# Altium schematic sheet loratea.SchDoc
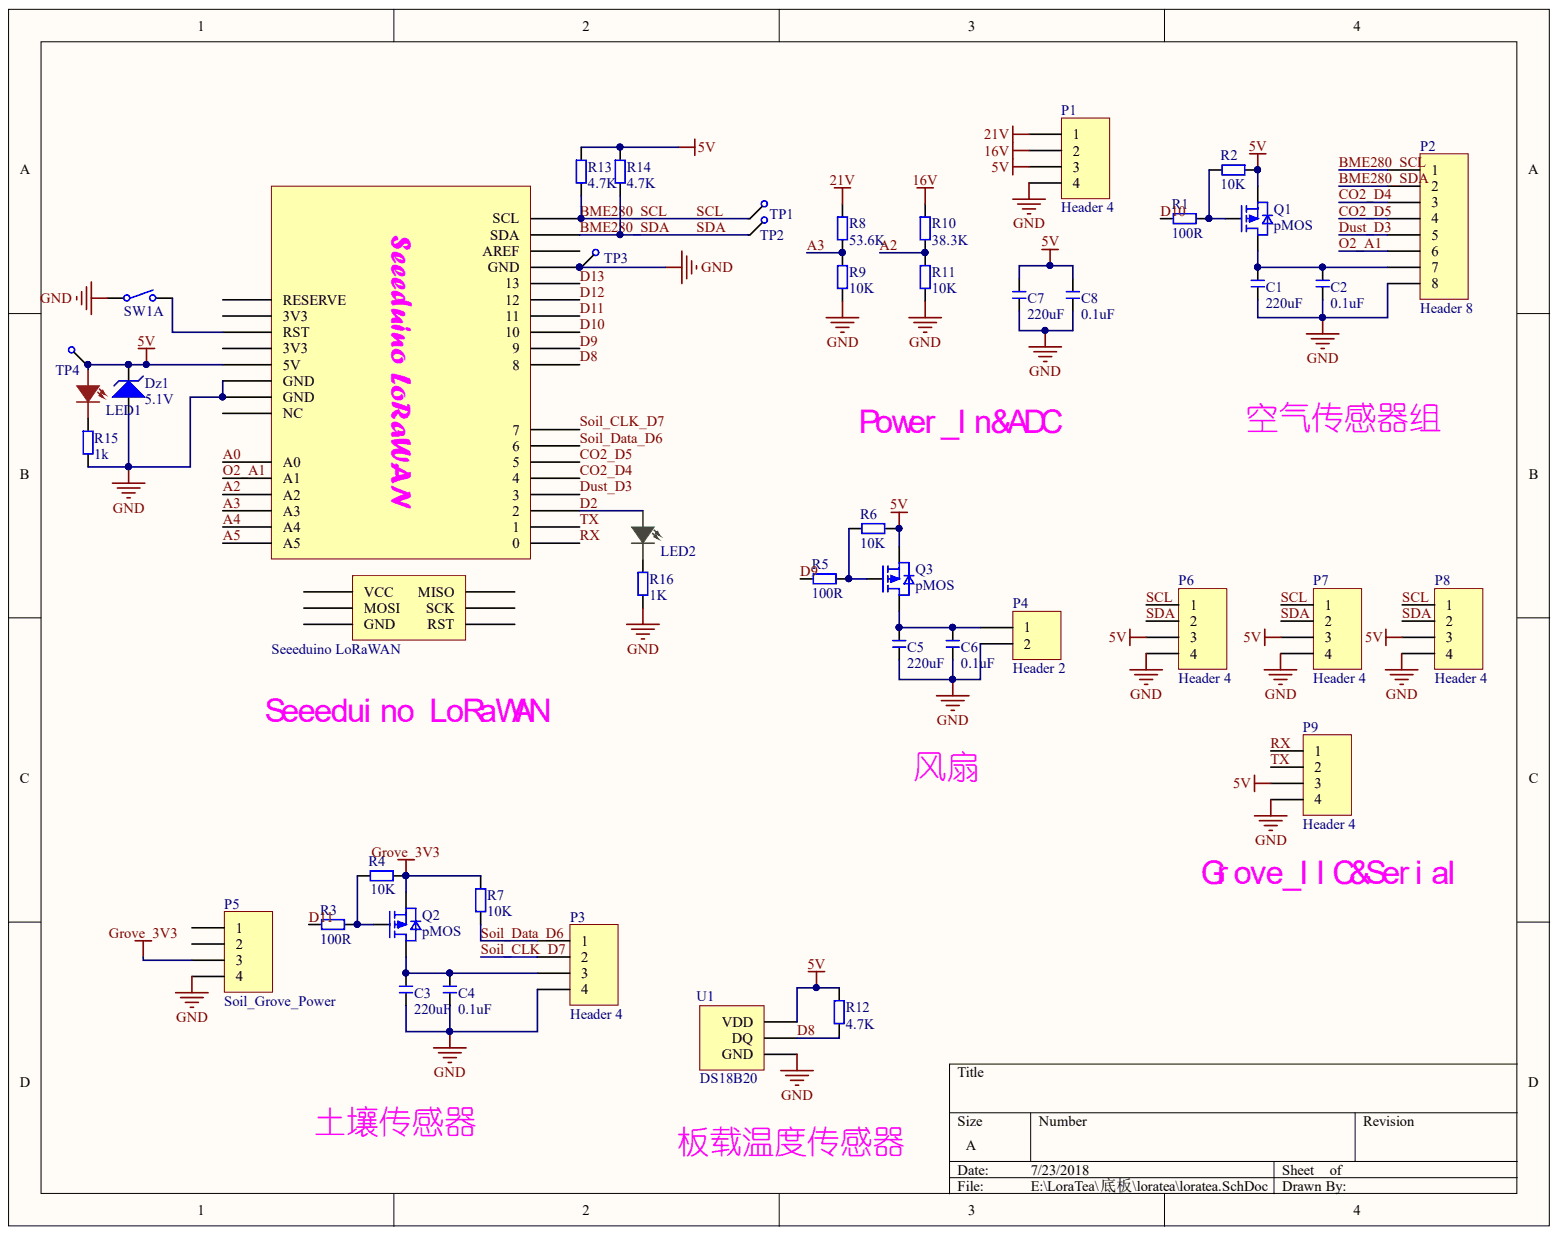
[diagram p0: loratea.SchDoc]

## Parts
C1  220uF  [C3528]
C2  0.1uF  [C0805]
C3  220uF  [C3528]
C4  0.1uF  [C0805]
C5  220uF  [C3528]
C6  0.1uF  [C0805]
C7  220uF  [C3528]
C8  0.1uF  [C0805]
Dz1  value 5.1V  [DIODE-0.2-SMD]  (hidden comment: Diode-Zener)
LED1  LED-R  [LED0805]  (value not drawn)
LED2  LED  [LED0805G]
P1  Header 4  [2EDG3.81-4P]
P2  Header 8  [2EDG3.81-8P]
P3  Header 4  [2EDG3.81-4P]
P4  Header 2  [2EDG3.81-2P]
P5  Soil_Grove_Power  [PH2.0-4]
P6  Header 4  [PH2.0-4]
P7  Header 4  [PH2.0-4]
P8  Header 4  [PH2.0-4]
P9  Header 4  [2EDG3.81-4P]
Q1  pMOS  [AO3415]
Q2  pMOS  [AO3415]
Q3  pMOS  [AO3415]
R1  100R  [R0805]
R2  value 10K  [R0805]  (hidden comment: 100R)
R3  100R  [R0805]
R4  value 10K  [R0805]  (hidden comment: 100R)
R5  100R  [R0805]
R6  value 10K  [R0805]  (hidden comment: 100R)
R7  value 10K  [R0805]  (hidden comment: 100R)
R8  53.6K  [R0805]
R9  10K  [R0805]
R10  38.3K  [R0805]
R11  10K  [R0805]
R12  4.7K  [R0805]
R13  4.7K  [R0805]
R14  4.7K  [R0805]
R15  1k  [R0805]
R16  1K  [R0805]
Seeeduino_LoRaWAN  Seeeduino LoRaWAN  [Seeeduino LoRaWAN]
SW1  SW-3s  [KEY6X6SMD]  (value not drawn)  (part A)
TP1  TestPoint  [TP]  (value not drawn)
TP2  TestPoint  [TP]  (value not drawn)
TP3  TestPoint  [TP]  (value not drawn)
TP4  TestPoint  [TP]  (value not drawn)
U1  DS18B20  [TO92C]

## Nets
5V: C7.1 C8.2 Dz1.2 LED1.1 P1.3 P6.3 P7.3 P8.3 P9.3 Q1.S Q3.S R2.2 R6.2 R12.2 R13.2 R14.2 Seeeduino_LoRaWAN.5V TP4.1 U1.3
16V: P1.2 R10.2
21V: P1.1 R8.2
GND: C1.2 C2.1 C3.2 C4.1 C5.2 C6.1 C7.2 C8.1 Dz1.1 P1.4 P2.8 P3.4 P4.2 P5.4 P6.4 P9.4 R9.1 R11.1 R15.1 R16.1 Seeeduino_LoRaWAN.GND SW1.1 TP3.1 U1.1
GROVE_3V3: P5.3 Q2.S R4.2 R7.2
A0: Seeeduino_LoRaWAN.A0
A2: R10.1 R11.2 Seeeduino_LoRaWAN.A2
A3: R8.1 R9.2 Seeeduino_LoRaWAN.A3
A4: Seeeduino_LoRaWAN.A4
A5: Seeeduino_LoRaWAN.A5
BME280_SCL: P2.1 P6.1 P7.1 P8.1 R13.1 Seeeduino_LoRaWAN.SCL TP1.1
BME280_SDA: P2.2 P6.2 P7.2 P8.2 R14.1 Seeeduino_LoRaWAN.SDA TP2.1
CO2_D4: P2.3 Seeeduino_LoRaWAN.4
CO2_D5: P2.4 Seeeduino_LoRaWAN.5
D2: LED2.1 Seeeduino_LoRaWAN.2
D8: R12.1 Seeeduino_LoRaWAN.8 U1.2
D9: R5.1 Seeeduino_LoRaWAN.9
D10: R1.1 Seeeduino_LoRaWAN.10
D11: R3.1 Seeeduino_LoRaWAN.7
D12: Seeeduino_LoRaWAN.12
D13: Seeeduino_LoRaWAN.13
DUST_D3: P2.5 Seeeduino_LoRaWAN.3
O2_A1: P2.6 Seeeduino_LoRaWAN.A1
RX: P9.1 Seeeduino_LoRaWAN.0
SOIL_CLK_D7: P3.2 Seeeduino_LoRaWAN.7
SOIL_DATA_D6: P3.1 R7.1 Seeeduino_LoRaWAN.6
TX: P9.2 Seeeduino_LoRaWAN.1
NetC1_1: C1.1 C2.2 P2.7 Q1.D
NetC3_1: C3.1 C4.2 P3.3 Q2.D
NetC5_1: C5.1 C6.2 P4.1 Q3.D
NetLED1_2: LED1.2 R15.2
NetLED2_2: LED2.2 R16.2
NetQ1_G: Q1.G R1.2 R2.1
NetQ2_G: Q2.G R3.2 R4.1
NetQ3_G: Q3.G R5.2 R6.1
NetSeeeduino LoRaWAN_RST: Seeeduino_LoRaWAN.RST SW1.2

Not connected: P5 1 2; P7 4; P8 4; Seeeduino_LoRaWAN 3V3 AREF MISO MOSI NC RESERVE SCK VCC

## Library data of the parts
C1-C8 = Cap: 无极电容; Component Kind: Standard; Library Name: lanfengdi.SchLib
  C1 C3 C5 C7: Value: 220uF
  C2 C4 C6 C8: Value: 0.1uF
Dz1 = Diode-Zener: 稳压二极管; Component Kind: Standard; Library Name: lanfengdi.SchLib
LED1 = LED-R: 红色发光二极管; Component Kind: Standard; Library Name: lanfengdi.SchLib
LED2 = LED: 绿色发光二极管; Component Kind: Standard; Library Name: lanfengdi.SchLib
P1 P3 P5-P9 = Header 4: Header, 4-Pin; Component Kind: Standard; LatestRevisionDate: 17-Jul-2002; LatestRevisionNote: Re-released for DXP Platform.; Library Name: Miscellaneous Connectors.IntLib; Signal Integrity: Connector; Publisher: Altium Limited
P2 = Header 8: Header, 8-Pin; Component Kind: Standard; LatestRevisionDate: 17-Jul-2002; LatestRevisionNote: Re-released for DXP Platform.; Library Name: Miscellaneous Connectors.IntLib; Signal Integrity: Connector; Publisher: Altium Limited
P4 = Header 2: Header, 2-Pin; Component Kind: Standard; LatestRevisionDate: 17-Jul-2002; LatestRevisionNote: Re-released for DXP Platform.; Library Name: Miscellaneous Connectors.IntLib; Signal Integrity: Connector; Publisher: Altium Limited
Q1-Q3 = pMOS-FET: 增强型P沟道金属氧化物半导体场效应晶体管; Component Kind: Standard; Library Name: lanfengdi.SchLib
R1-R16 = RES: 电阻  RES; Component Kind: Standard
  R1 R3 R5: Value: 100R
  R8: Value: 53.6K
  R9 R11: Value: 10K
  R10: Value: 38.3K
  R12-R14: Value: 4.7K
  R15: Value: 1k
  R16: Value: 1K
  R1-R5 R7-R16: Library Name: lanfengdi.SchLib
  R6: Library Name: lanfengi.SchLib
SW1 = SW-3s: 开关排，3位; Component Kind: Standard; Library Name: lanfengdi.SchLib
TP1-TP4 = TestPoint: 一个测试点; Component Kind: Standard; Library Name: lanfengdi.SchLib
U1 = DS18B20: 单总线温度传感器; Component Kind: Standard; Library Name: lanfengdi.SchLib
Seeeduino_LoRaWAN = Seeeduino LoRaWAN: Component Kind: Standard; Library Name: Schlib1.SchLib
